# Altium schematic sheet lidsense.SchDoc(lidsense)
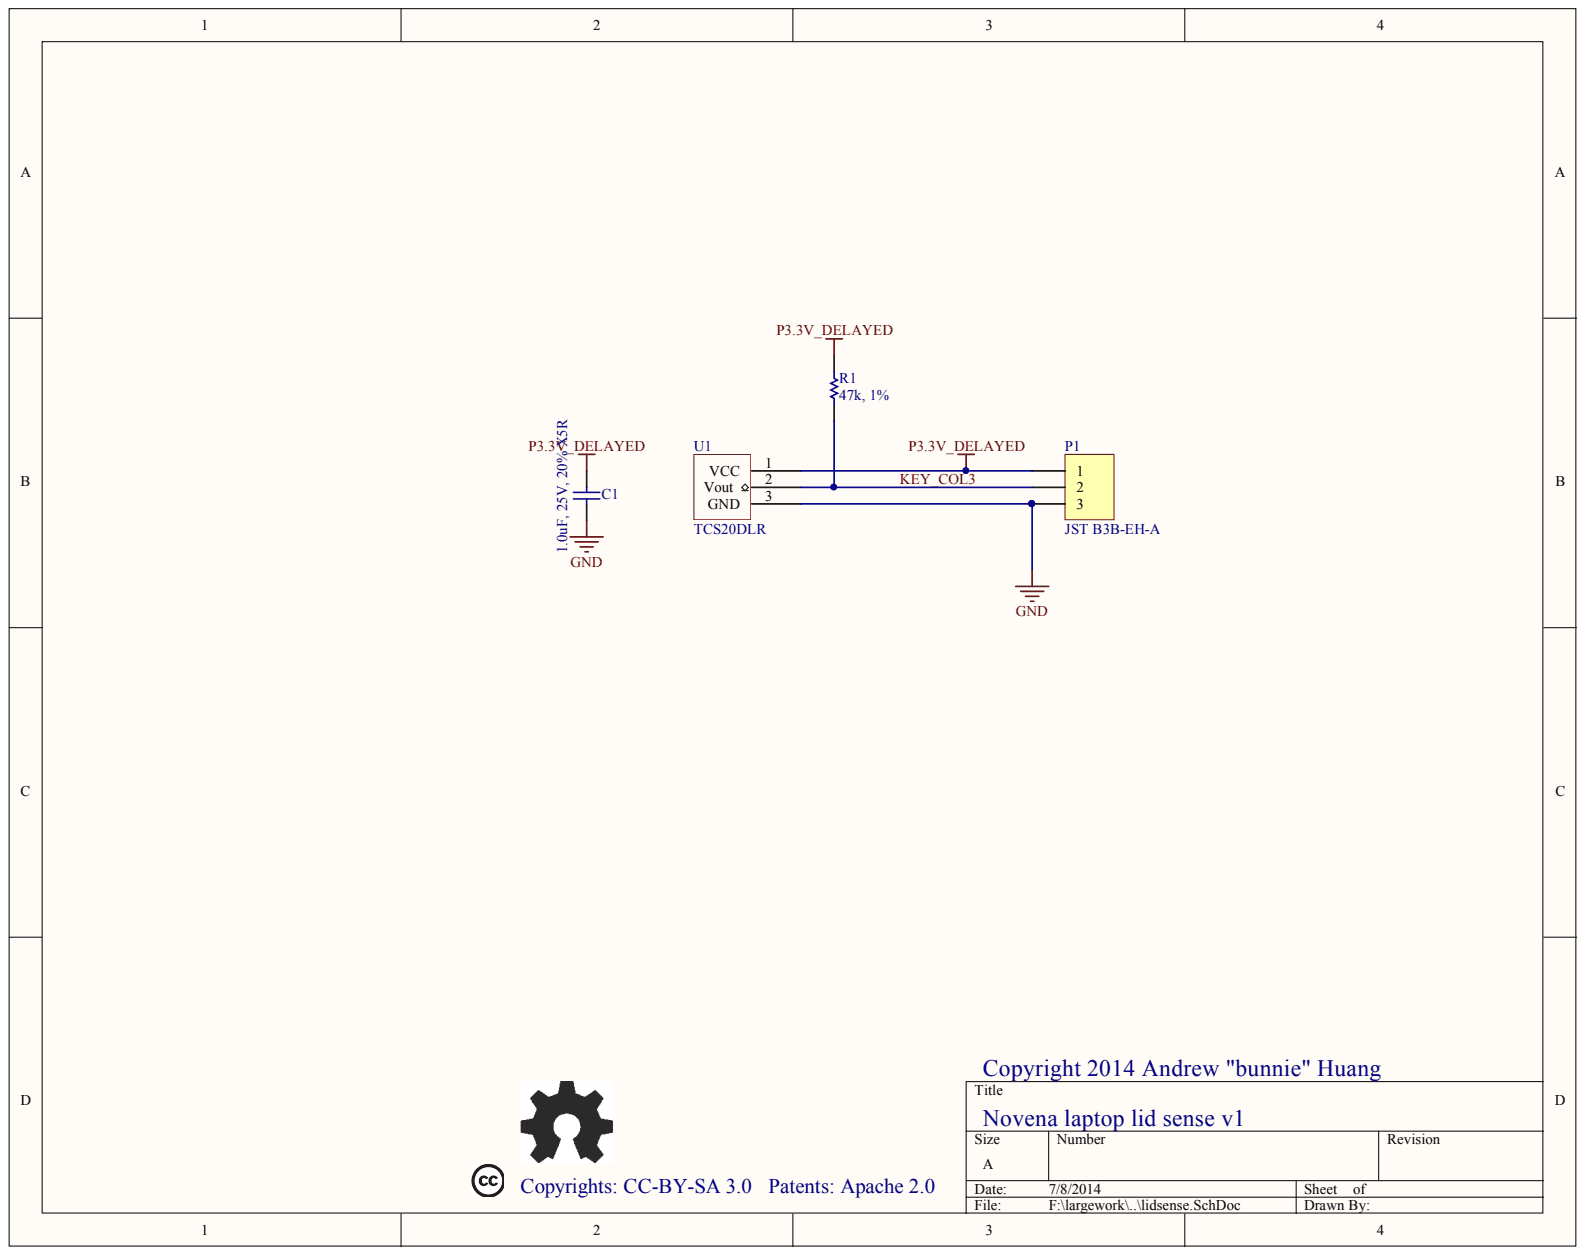
[diagram p0: lidsense.SchDoc]

## Parts
C1  1.0uF, 25V, 20% X5R
P1  JST B3B-EH-A
R1  47k, 1%
U1  TCS20DLR

## Nets
GND: C1.2 P1.3 U1.3
P3.3V_DELAYED: C1.1 P1.1 R1.1 U1.1
KEY_COL3: P1.2 R1.2 U1.2

## Library data of the parts
R1 = (no symbol name): Value: 100k; Published: 8-Jun-2000; LatestRevisionDate: 17-Jul-2002; LatestRevisionNote: Re-released for DXP Platform.; PackageReference: AXIAL-0.3; Publisher: Altium Limited
C1 P1 U1 = (no symbol name)
  C1: Published: 8-Jun-2000; LatestRevisionDate: 17-Jul-2002; LatestRevisionNote: Re-released for DXP Platform.; PackageReference: C1206
  P1: LatestRevisionDate: 17-Jul-2002; LatestRevisionNote: Re-released for DXP Platform.
  C1 P1: Publisher: Altium Limited
